# Altium schematic sheet PULSE.SchDoc(PULSE)
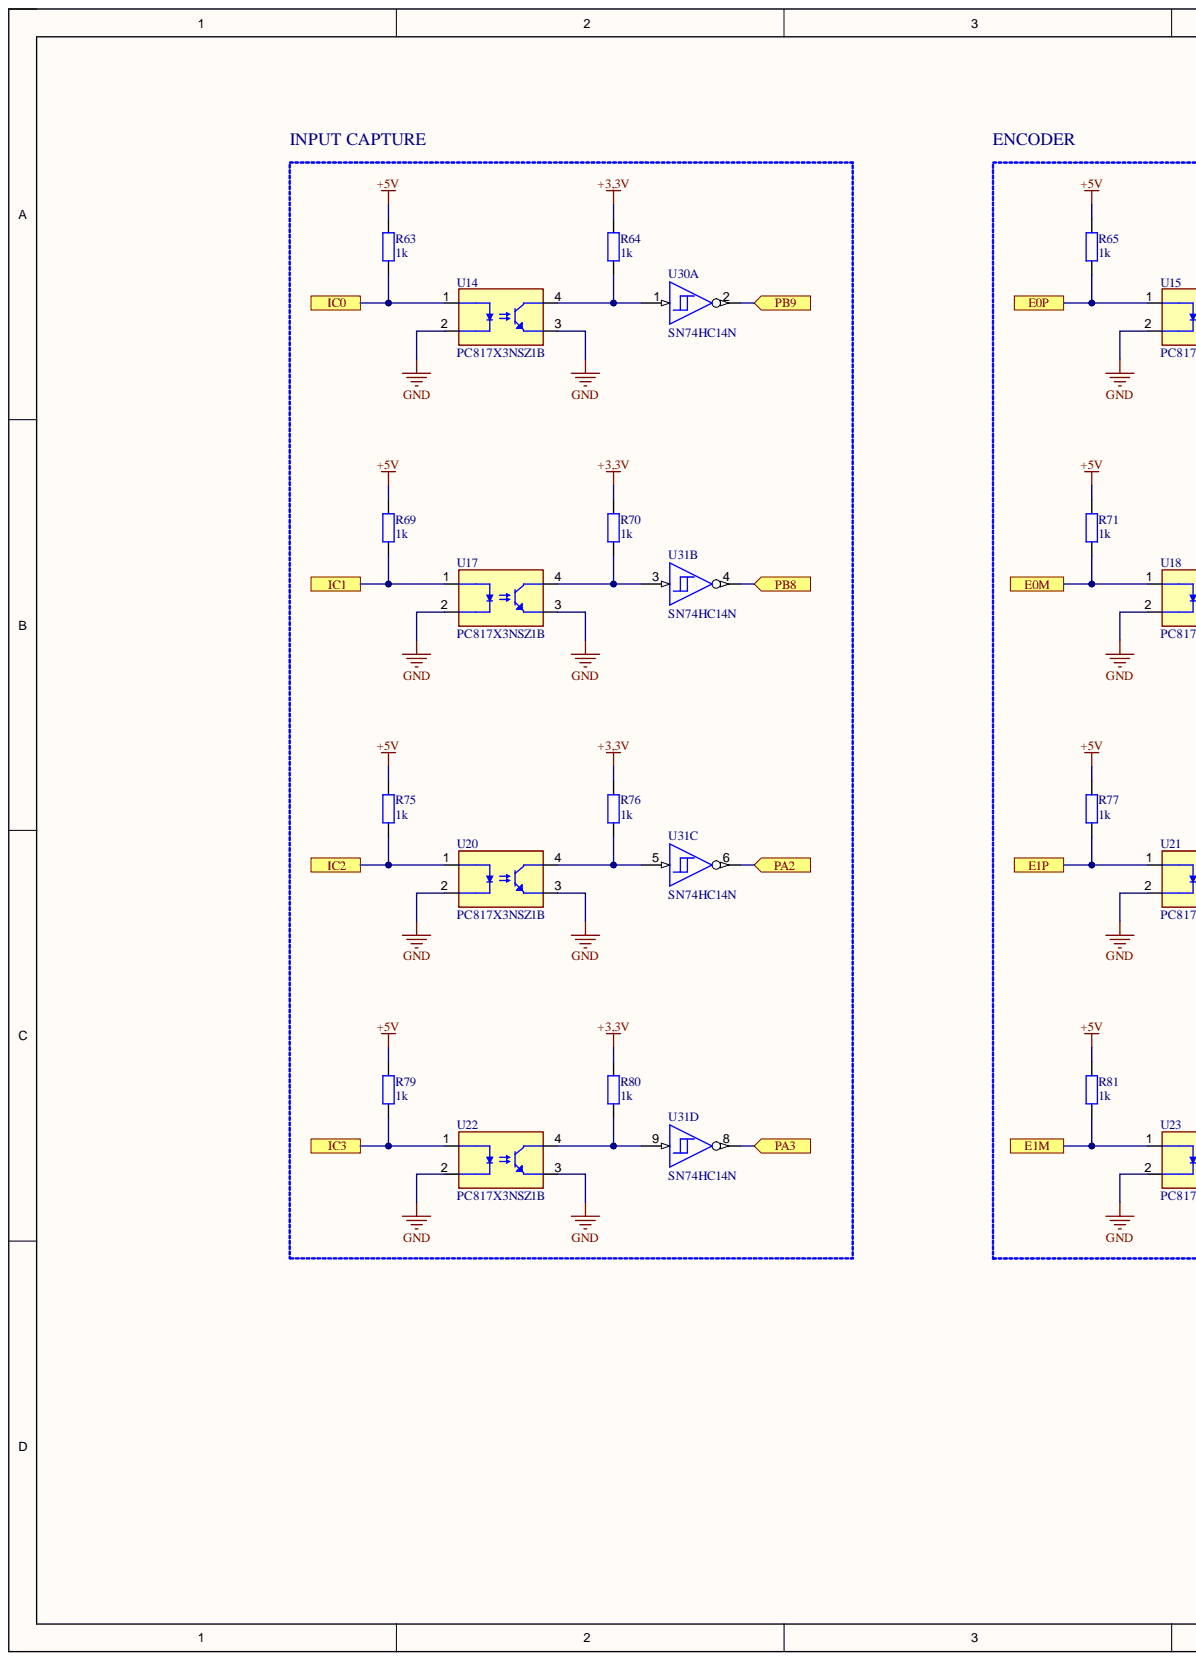
[diagram p0: PULSE.SchDoc, left]
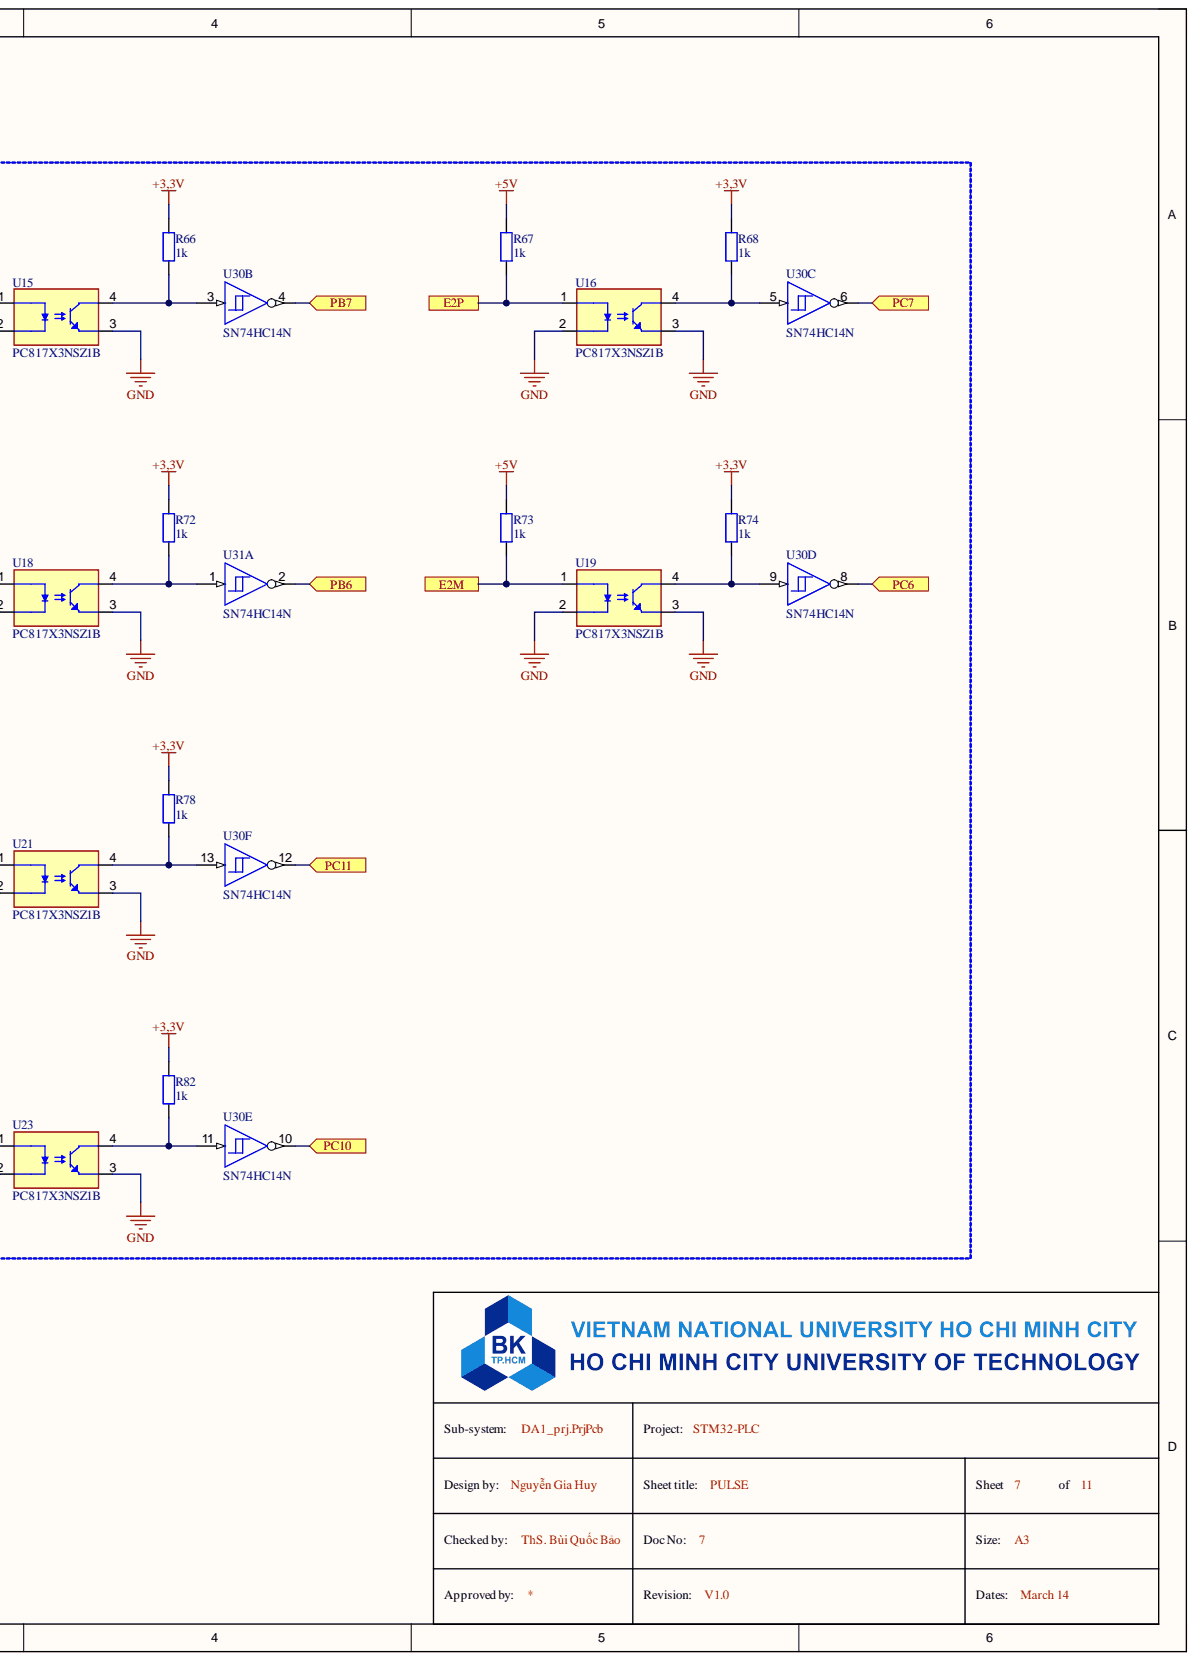
[diagram p1: PULSE.SchDoc, right]

## Parts
R63  1k  [tro smd 0603]
R64  1k  [tro smd 0603]
R65  1k  [tro smd 0603]
R66  1k  [tro smd 0603]
R67  1k  [tro smd 0603]
R68  1k  [tro smd 0603]
R69  1k  [tro smd 0603]
R70  1k  [tro smd 0603]
R71  1k  [tro smd 0603]
R72  1k  [tro smd 0603]
R73  1k  [tro smd 0603]
R74  1k  [tro smd 0603]
R75  1k  [tro smd 0603]
R76  1k  [tro smd 0603]
R77  1k  [tro smd 0603]
R78  1k  [tro smd 0603]
R79  1k  [tro smd 0603]
R80  1k  [tro smd 0603]
R81  1k  [tro smd 0603]
R82  1k  [tro smd 0603]
U14  PC817X3NSZ1B  [DIP762W50P254L495H455Q4]
U15  PC817X3NSZ1B  [DIP762W50P254L495H455Q4]
U16  PC817X3NSZ1B  [DIP762W50P254L495H455Q4]
U17  PC817X3NSZ1B  [DIP762W50P254L495H455Q4]
U18  PC817X3NSZ1B  [DIP762W50P254L495H455Q4]
U19  PC817X3NSZ1B  [DIP762W50P254L495H455Q4]
U20  PC817X3NSZ1B  [DIP762W50P254L495H455Q4]
U21  PC817X3NSZ1B  [DIP762W50P254L495H455Q4]
U22  PC817X3NSZ1B  [DIP762W50P254L495H455Q4]
U23  PC817X3NSZ1B  [DIP762W50P254L495H455Q4]
U30  SN74HC14N  [N0014A]  (parts A,B,C,D,E,F)
U31  SN74HC14N  [N0014A]  (parts A,B,C,D)

## Ports
E0M, E0P, E1M, E1P, E2M, E2P, IC0, IC1, IC2, IC3, PA2, PA3, PB6, PB7, PB8, PB9, PC6, PC7, PC10, PC11

## Nets
+3,3V: R64.2 R66.2 R68.2 R70.2 R72.2 R74.2 R76.2 R78.2 R80.2 R82.2
+5V: R63.2 R65.2 R67.2 R69.2 R71.2 R73.2 R75.2 R77.2 R79.2 R81.2
GND: U14.2 U14.3 U15.2 U15.3 U16.2 U16.3 U17.2 U17.3 U18.2 U18.3 U19.2 U19.3 U20.2 U20.3 U21.2 U21.3 U22.2 U22.3 U23.2 U23.3
E0M: R71.1 U18.1 ; port E0M
E0P: R65.1 U15.1 ; port E0P
E1M: R81.1 U23.1 ; port E1M
E1P: R77.1 U21.1 ; port E1P
E2M: R73.1 U19.1 ; port E2M
E2P: R67.1 U16.1 ; port E2P
IC0: R63.1 U14.1 ; port IC0
IC1: R69.1 U17.1 ; port IC1
IC2: R75.1 U20.1 ; port IC2
IC3: R79.1 U22.1 ; port IC3
PA2: U31.6 ; port PA2
PA3: U31.8 ; port PA3
PB6: U31.2 ; port PB6
PB7: U30.4 ; port PB7
PB8: U31.4 ; port PB8
PB9: U30.2 ; port PB9
PC6: U30.8 ; port PC6
PC7: U30.6 ; port PC7
PC10: U30.10 ; port PC10
PC11: U30.12 ; port PC11
NetR64_1: R64.1 U14.4 U30.1
NetR66_1: R66.1 U15.4 U30.3
NetR68_1: R68.1 U16.4 U30.5
NetR70_1: R70.1 U17.4 U31.3
NetR72_1: R72.1 U18.4 U31.1
NetR74_1: R74.1 U19.4 U30.9
NetR76_1: R76.1 U20.4 U31.5
NetR78_1: R78.1 U21.4 U30.13
NetR80_1: R80.1 U22.4 U31.9
NetR82_1: R82.1 U23.4 U30.11

## Library data of the parts
R63-R82 = Tro 0603 1% 10k: RES; Chung_Loai: Dán; Don_Vi: Con; Ma_Hang: MH006333; So_Chan: 2; Ten_Linh_Kien: Trở 0603 1% 10k; Component Kind: Standard; Library Name: AUSB.SchLib
U14-U23 = PC817X3NSZ1B: Optoisolator Transistor Output - 1 Channel 4-DIP; MF: Sharp Microelectronics; Availability: In Stock; Check_prices: https://www.snapeda.com/parts/PC817X3NSZ1B/Sharp+Microelectronics/view-part/?ref=eda; Component Kind: Standard; Library Name: PC817X3NSZ1B.IntLib; MP: PC817X3NSZ1B; Package: DIP-4 Sharp Microelectronics; Price: None; Purchase-URL: https://pricing.snapeda.com/search/part/PC817X3NSZ1B/?ref=eda; SnapEDA_Link: https://www.snapeda.com/parts/PC817X3NSZ1B/Sharp+Microelectronics/view-part/?ref=snap
U30 U31 = CMP-1615-00889-3: Hex Schmitt-Trigger Inverters, N0014A, TUBE; Manufacturer: Texas Instruments; Bits(#): 6; F @ Nom Voltage(Max)(Mhz): 20; ICC @ Nom Voltage(Max)(mA): 0.02; Input Type: CMOS; Output Drive (IOL/IOH)(Max)(mA): -4/4; Rating: Catalog; Schmitt Trigger: Yes; Technology Family: HC; tpd @ Nom Voltage(Max)(ns): 31; VCC(Min)(V): 2; Voltage(Nom)(V): 5; Code_JEDEC: MS-001; Component Kind: Standard; DatasheetVersion: SCLS085G; Generic Part Number: SN74HC14; Library Name: SN74HC14N.IntLib; Operating Temperature Range(C): -40 to 85; Output Type: CMOS; PackageReference: N0014A; VCC(Max)(V): 6
